# Altium schematic sheet 02_ATK-DLMP257_Core_Board.SchDoc
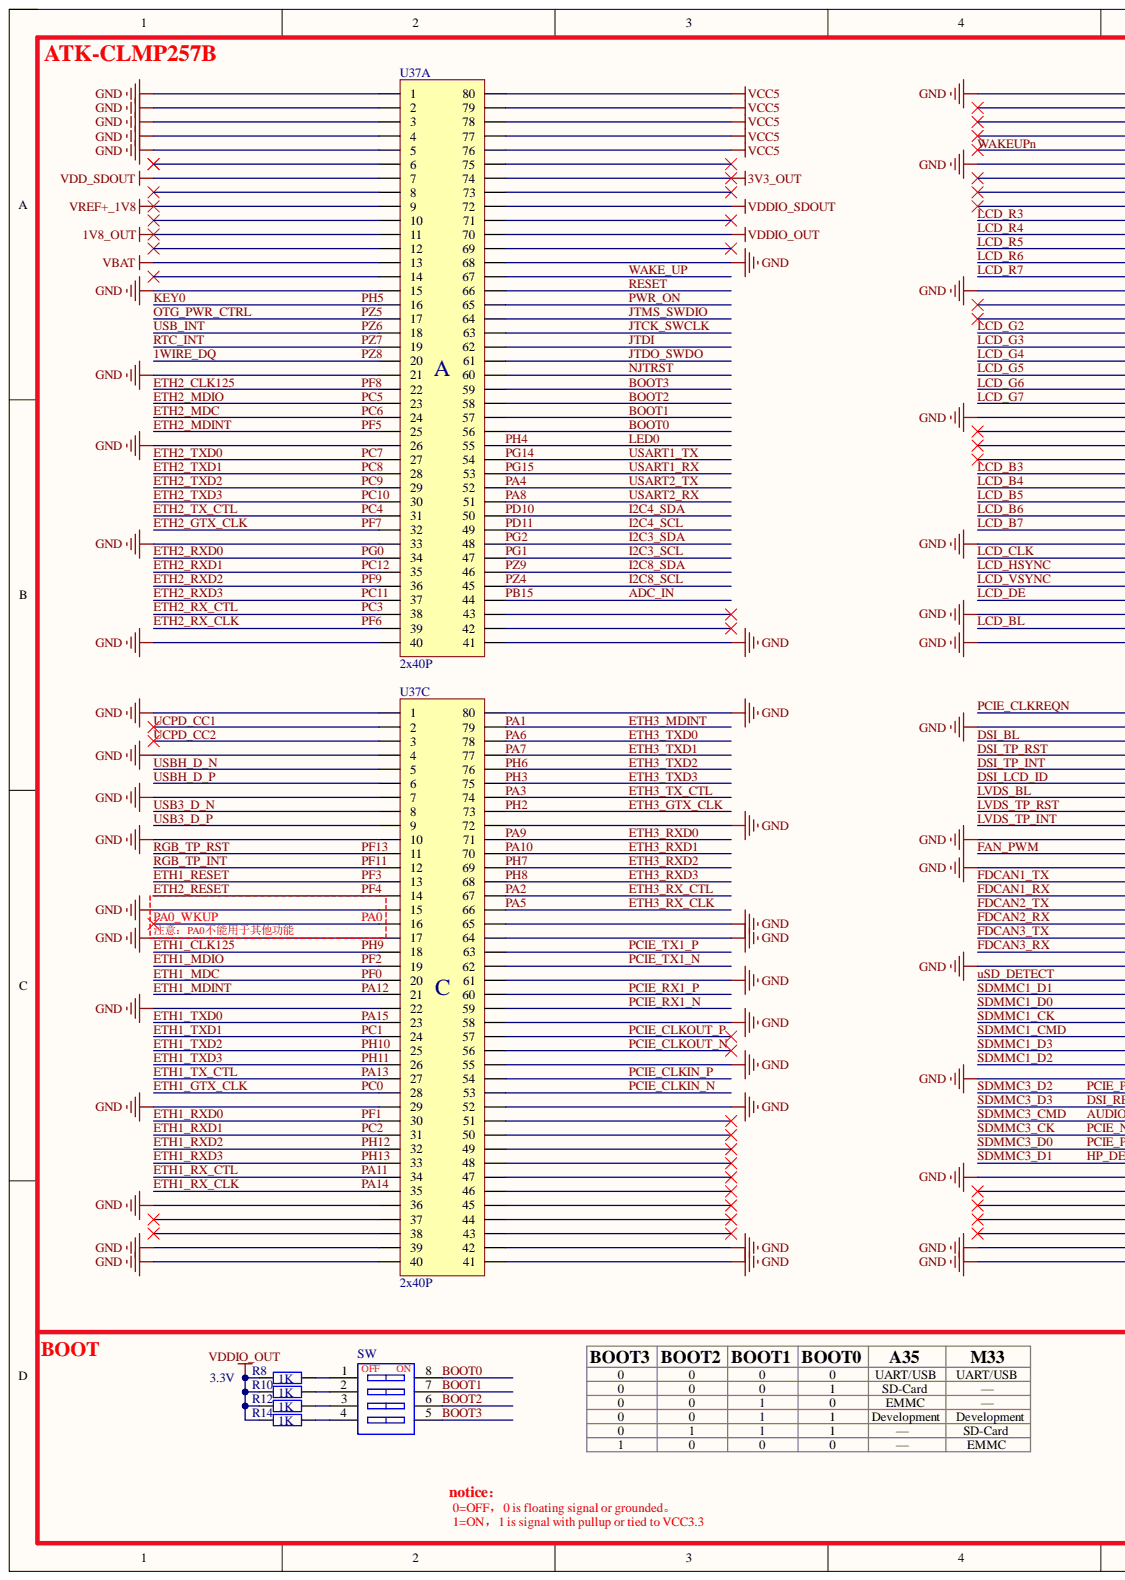
[diagram p0: 02_ATK-DLMP257_Core_Board.SchDoc, left]
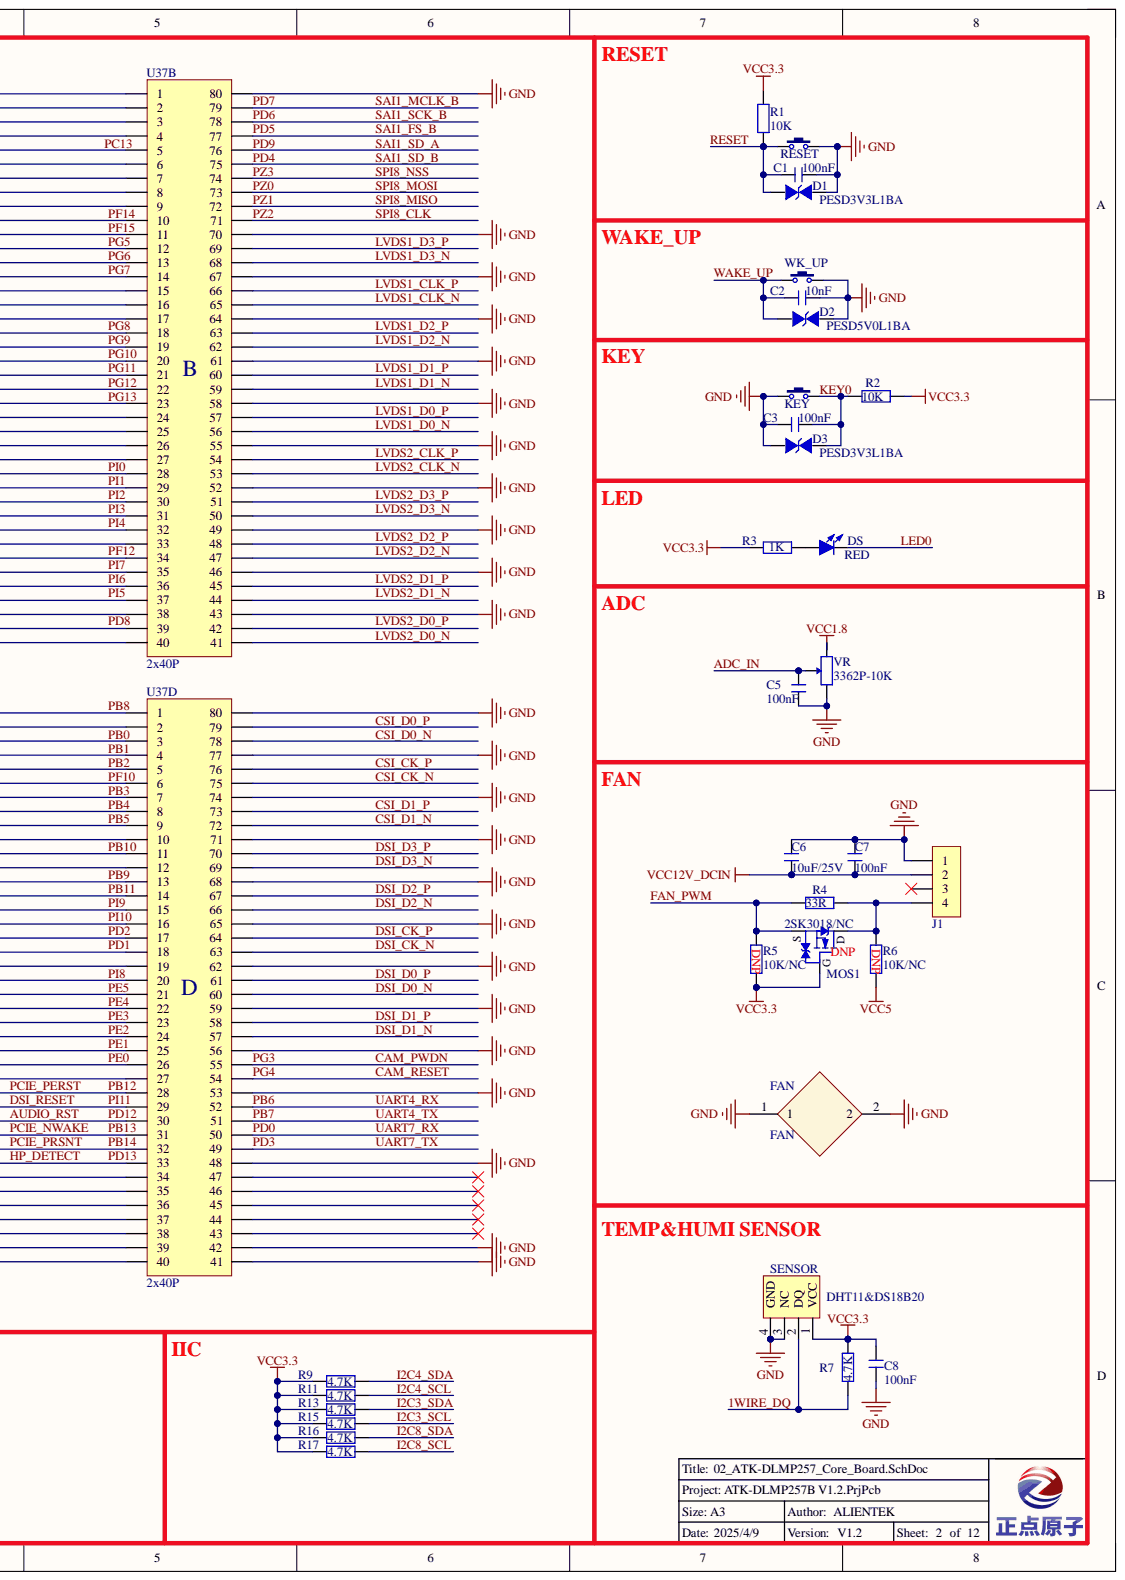
[diagram p1: 02_ATK-DLMP257_Core_Board.SchDoc, right]

## Parts
C1  100nF  [C 0603]
C2  10nF  [C 0603]
C3  100nF  [C 0603]
C5  100nF  [C 0603]
C6  10uF/25V  [C 0805]
C7  100nF  [C 0603]
C8  100nF  [C 0603]
D1  PESD3V3L1BA  [SOD-323]
D2  PESD5V0L1BA  [SOD-323]
D3  PESD3V3L1BA  [SOD-323]
DS  RED  [LED 0805G]
FAN  FAN  [40X40MM_FAN_59MM]
J1  FAN-HEADER  [PH2.0-4P V-T]  (value not drawn)
KEY  KEY  [KEY_SOP324225]
MOS1  2SK3018/NC  [SOT323-3L]
R1  10K  [R 0603]
R2  10K  [R 0603]
R3  1K  [R 0603]
R4  33R  [R 0603]
R5  10K/NC  [R 0603]
R6  10K/NC  [R 0603]
R7  4.7K  [R 0603]
R8  1K  [R 0603]
R9  4.7K  [R 0603]
R10  1K  [R 0603]
R11  4.7K  [R 0603]
R12  1K  [R 0603]
R13  4.7K  [R 0603]
R14  1K  [R 0603]
R15  4.7K  [R 0603]
R16  4.7K  [R 0603]
R17  4.7K  [R 0603]
RESET  KEY  [KEY_SOP324225]  (value not drawn)
SENSOR  DHT11&DS18B20  [DHT11]
SW  CSW-4P  [CSW DIP-4P]  (value not drawn)
U37  2x40P  [ATK-CLMP257B-CORE]  (parts A,B,C,D)
VR  3362P-10K  [3362P]
WK_UP  KEY  [KEY_SOP324225]  (value not drawn)

## Nets
1V8_OUT: U37.A11
3V3_OUT: U37.A74
GND: C1.2 C2.2 C3.1 C5.1 C6.2 C7.2 C8.1 D1.2 D2.2 D3.2 FAN.1 FAN.2 J1.1 KEY.1 RESET.2 SENSOR.3(NC) SENSOR.4(GND) U37.A1 U37.A2 U37.A3 U37.A4 U37.A5 U37.A15 U37.A21 U37.A26 U37.A33 U37.A40 U37.A41 U37.A68 U37.B1 U37.B6 U37.B15 U37.B24 U37.B33 U37.B38 U37.B40 U37.B43 U37.B46 U37.B49 U37.B52 U37.B55 U37.B58 U37.B61 U37.B64 U37.B67 U37.B70 U37.B80 U37.C1 U37.C4 U37.C7 U37.C10 U37.C15 U37.C17 U37.C22 U37.C29 U37.C36 U37.C39 U37.C40 U37.C41 U37.C42 U37.C52 U37.C55 U37.C58 U37.C61 U37.C64 U37.C65 U37.C72 U37.C80 U37.D2 U37.D10 U37.D12 U37.D19 U37.D27 U37.D34 U37.D39 U37.D40 U37.D41 U37.D42 U37.D48 U37.D53 U37.D56 U37.D59 U37.D62 U37.D65 U37.D68 U37.D71 U37.D74 U37.D77 U37.D80 VR.1 WK_UP.2
VBAT: U37.A13
VCC1.8: VR.3
VCC3.3: C8.2 MOS1.1 R1.2 R2.1 R3.2 R5.2 R7.2 R9.2 R11.2 R13.2 R15.2 R16.2 R17.2 SENSOR.1(VCC)
VCC5: R6.2 U37.A76 U37.A77 U37.A78 U37.A79 U37.A80
VCC12V_DCIN: C6.1 C7.1 J1.2
VDD_SDOUT: U37.A7
VDDIO_OUT: R8.1 R10.1 R12.1 R14.1 U37.A70
VDDIO_SDOUT: U37.A72
VREF+_1V8: U37.A9
1WIRE_DQ: R7.1 SENSOR.2(DQ) U37.A20
ADC_IN: C5.2 U37.A44 VR.2
AUDIO_RST: U37.D30
BOOT0: SW.8 U37.A56
BOOT1: SW.7 U37.A57
BOOT2: SW.6 U37.A58
BOOT3: SW.5 U37.A59
CAM_PWDN: U37.D55
CAM_RESET: U37.D54
CSI_CK_N: U37.D75
CSI_CK_P: U37.D76
CSI_D0_N: U37.D78
CSI_D0_P: U37.D79
CSI_D1_N: U37.D72
CSI_D1_P: U37.D73
DSI_BL: U37.D3
DSI_CK_N: U37.D63
DSI_CK_P: U37.D64
DSI_D0_N: U37.D60
DSI_D0_P: U37.D61
DSI_D1_N: U37.D57
DSI_D1_P: U37.D58
DSI_D2_N: U37.D66
DSI_D2_P: U37.D67
DSI_D3_N: U37.D69
DSI_D3_P: U37.D70
DSI_LCD_ID: U37.D6
DSI_RESET: U37.D29
DSI_TP_INT: U37.D5
DSI_TP_RST: U37.D4
ETH1_CLK125: U37.C18
ETH1_GTX_CLK: U37.C28
ETH1_MDC: U37.C20
ETH1_MDINT: U37.C21
ETH1_MDIO: U37.C19
ETH1_RESET: U37.C13
ETH1_RX_CLK: U37.C35
ETH1_RX_CTL: U37.C34
ETH1_RXD0: U37.C30
ETH1_RXD1: U37.C31
ETH1_RXD2: U37.C32
ETH1_RXD3: U37.C33
ETH1_TX_CTL: U37.C27
ETH1_TXD0: U37.C23
ETH1_TXD1: U37.C24
ETH1_TXD2: U37.C25
ETH1_TXD3: U37.C26
ETH2_CLK125: U37.A22
ETH2_GTX_CLK: U37.A32
ETH2_MDC: U37.A24
ETH2_MDINT: U37.A25
ETH2_MDIO: U37.A23
ETH2_RESET: U37.C14
ETH2_RX_CLK: U37.A39
ETH2_RX_CTL: U37.A38
ETH2_RXD0: U37.A34
ETH2_RXD1: U37.A35
ETH2_RXD2: U37.A36
ETH2_RXD3: U37.A37
ETH2_TX_CTL: U37.A31
ETH2_TXD0: U37.A27
ETH2_TXD1: U37.A28
ETH2_TXD2: U37.A29
ETH2_TXD3: U37.A30
ETH3_GTX_CLK: U37.C73
ETH3_MDINT: U37.C79
ETH3_RX_CLK: U37.C66
ETH3_RX_CTL: U37.C67
ETH3_RXD0: U37.C71
ETH3_RXD1: U37.C70
ETH3_RXD2: U37.C69
ETH3_RXD3: U37.C68
ETH3_TX_CTL: U37.C74
ETH3_TXD0: U37.C78
ETH3_TXD1: U37.C77
ETH3_TXD2: U37.C76
ETH3_TXD3: U37.C75
FAN_PWM: MOS1.2 R4.1 R5.1 U37.D11
FDCAN1_RX: U37.D14
FDCAN1_TX: U37.D13
FDCAN2_RX: U37.D16
FDCAN2_TX: U37.D15
FDCAN3_RX: U37.D18
FDCAN3_TX: U37.D17
HP_DETECT: U37.D33
I2C3_SCL: R15.1 U37.A47
I2C3_SDA: R13.1 U37.A48
I2C4_SCL: R11.1 U37.A49
I2C4_SDA: R9.1 U37.A50
I2C8_SCL: R17.1 U37.A45
I2C8_SDA: R16.1 U37.A46
JTCK_SWCLK: U37.A63
JTDI: U37.A62
JTDO_SWDO: U37.A61
JTMS_SWDIO: U37.A64
KEY0: C3.2 D3.1 KEY.2 R2.2 U37.A16
LCD_B3: U37.B28
LCD_B4: U37.B29
LCD_B5: U37.B30
LCD_B6: U37.B31
LCD_B7: U37.B32
LCD_BL: U37.B39
LCD_CLK: U37.B34
LCD_DE: U37.B37
LCD_G2: U37.B18
LCD_G3: U37.B19
LCD_G4: U37.B20
LCD_G5: U37.B21
LCD_G6: U37.B22
LCD_G7: U37.B23
LCD_HSYNC: U37.B35
LCD_R3: U37.B10
LCD_R4: U37.B11
LCD_R5: U37.B12
LCD_R6: U37.B13
LCD_R7: U37.B14
LCD_VSYNC: U37.B36
LED0: DS.2 U37.A55
LVDS1_CLK_N: U37.B65
LVDS1_CLK_P: U37.B66
LVDS1_D0_N: U37.B56
LVDS1_D0_P: U37.B57
LVDS1_D1_N: U37.B59
LVDS1_D1_P: U37.B60
LVDS1_D2_N: U37.B62
LVDS1_D2_P: U37.B63
LVDS1_D3_N: U37.B68
LVDS1_D3_P: U37.B69
LVDS2_CLK_N: U37.B53
LVDS2_CLK_P: U37.B54
LVDS2_D0_N: U37.B41
LVDS2_D0_P: U37.B42
LVDS2_D1_N: U37.B44
LVDS2_D1_P: U37.B45
LVDS2_D2_N: U37.B47
LVDS2_D2_P: U37.B48
LVDS2_D3_N: U37.B50
LVDS2_D3_P: U37.B51
LVDS_BL: U37.D7
LVDS_TP_INT: U37.D9
LVDS_TP_RST: U37.D8
NJTRST: U37.A60
OTG_PWR_CTRL: U37.A17
PA0: U37.C16
PA4: U37.A52
PA8: U37.A51
PB6: U37.D52
PB7: U37.D51
PB8: U37.D1
PB12: U37.D28
PB13: U37.D31
PB14: U37.D32
PC13: U37.B5
PCIE_CLKIN_N: U37.C53
PCIE_CLKIN_P: U37.C54
PCIE_CLKOUT_N: U37.C56
PCIE_CLKOUT_P: U37.C57
PCIE_RX1_N: U37.C59
PCIE_RX1_P: U37.C60
PCIE_TX1_N: U37.C62
PCIE_TX1_P: U37.C63
PD0: U37.D50
PD3: U37.D49
PD4: U37.B75
PD5: U37.B77
PD6: U37.B78
PD7: U37.B79
PD9: U37.B76
PE0: U37.D26
PE1: U37.D25
PE2: U37.D24
PE3: U37.D23
PE4: U37.D22
PE5: U37.D21
PF11: U37.C12
PF13: U37.C11
PG14: U37.A54
PG15: U37.A53
PI8: U37.D20
PWR_ON: U37.A65
PZ0: U37.B73
PZ1: U37.B72
PZ2: U37.B71
PZ3: U37.B74
PZ6: U37.A18
PZ7: U37.A19
RESET: C1.1 D1.1 R1.1 RESET.1 U37.A66
UCPD_CC1: U37.C2
UCPD_CC2: U37.C3
USB3_D_N: U37.C8
USB3_D_P: U37.C9
USBH_D_N: U37.C5
USBH_D_P: U37.C6
WAKE_UP: C2.1 D2.1 U37.A67 WK_UP.1
NetDS_1: DS.1 R3.1
NetJ1_4: J1.4 MOS1.3 R4.2 R6.1
NetR8_2: R8.2 SW.1
NetR10_2: R10.2 SW.2
NetR12_2: R12.2 SW.3
NetR14_2: R14.2 SW.4

Not connected: J1 3; U37 A6 A8 A10 A12 A14 A42 A43 A69 A71 A73 A75 B2 B3 B4 B7 B8 B9 B16 B17 B25 B26 B27 C37 C38 C43 C44 C45 C46 C47 C48 C49 C50 C51 D35 D36 D37 D38 D43 D44 D45 D46 D47

## Library data of the parts
C1 C2 C5 C8 = C: 无极性贴片电容; 版权归属: 正点原子; BOM名称: 无极性贴片电容; Component Kind: Standard; 学习资源: www.openedv.com
  C5: Library Name: 电阻-电容-电感.SchLib
  C1 C2 C8: Library Name: Lycreturn.IntLib
C3 C6 C7 = C: 无极性电容; BOM名称: 无极性贴片电容; 版权归属: 正点原子; Component Kind: Standard; Library Name: 正点原子封装库V1.0.IntLib; 学习资源: www.openedv.com
D1-D3 = PESD5V0L1BA: ESD-静电保护TVS管; Component Kind: Standard; Library Name: 正点原子封装库V1.0.IntLib
DS = LED-SMD: 贴片LED; 版权归属: 正点原子; BOM名称: 贴片LED; Component Kind: Standard; Library Name: IMX6UL_ALPHA_V1.4.IntLib; 学习资源: www.openedv.com
FAN = FAN: 风扇; Component Kind: Standard; Library Name: ATK-DLMP257_V1.0.IntLib
J1 = Header 4: 4P接插件; Parameter: 接插件; 版权归属: 正点原子; BOM名称: 4P接插件; Component Kind: Standard; Library Name: 正点原子封装库V1.0.IntLib; 学习资源: www.openedv.com
KEY RESET WK_UP = KEY: 按键; BOM名称: 3x6轻触开关; 版权归属: 正点原子; Component Kind: Standard; 学习资源: www.openedv.com; Library Name: Lycreturn.IntLib
MOS1 = 2SK3018: NMOS  30V 0.1A@2.5V 8Ω; BOM名称: N沟场效应管; Parameter: MOS管; 版权归属: 正点原子; Component Kind: Standard; Library Name: ATK-DLMP257_V1.0.IntLib; 学习资源: www.openedv.com
R1-R17 = R: 贴片电阻; 版权归属: 正点原子; BOM名称: 贴片电阻; Component Kind: Standard; 学习资源: www.openedv.com; Library Name: Lycreturn.IntLib
SENSOR = DHT11: 数字温湿度传感器; Component Kind: Standard; Library Name: 阿波罗STM32F4&F7底板封装库.IntLib
SW = CSW-4P: 4路编码开关; Parameter: 按键/开关; 版权归属: 正点原子; BOM名称: 4路编码开关; Component Kind: Standard; 学习资源: www.openedv.com; Library Name: Lycreturn.IntLib
U37 = CLMP257B-CORE: Component Kind: Standard; Description: CLMP257B-CORE; Library Name: ATK-DLMP257B V1.1.SCHLIB
VR = RP-ID: 插件单联电位器; BOM名称: 插件电位器; 版权归属: 正点原子; Component Kind: Standard; 学习资源: www.openedv.com; Library Name: Lycreturn.IntLib
